# Altium schematic sheet Peripherals Schematic.SchDoc("Peripherals Schematic")
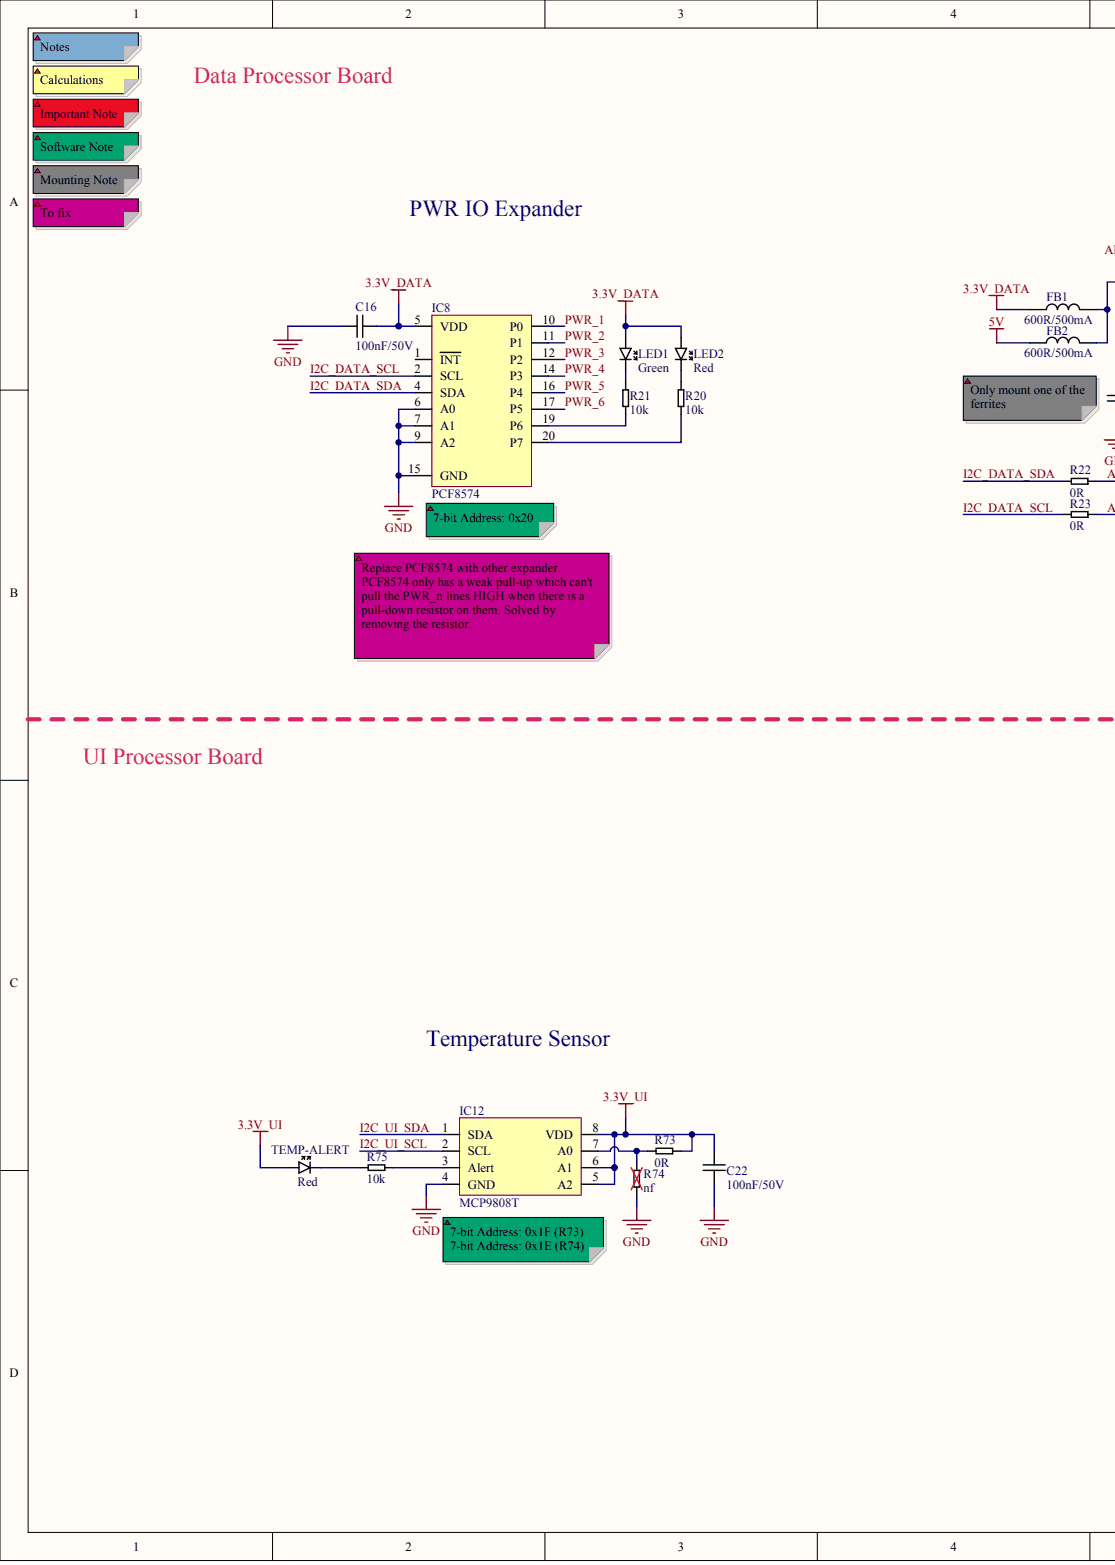
[diagram p0: Peripherals Schematic.SchDoc, left]
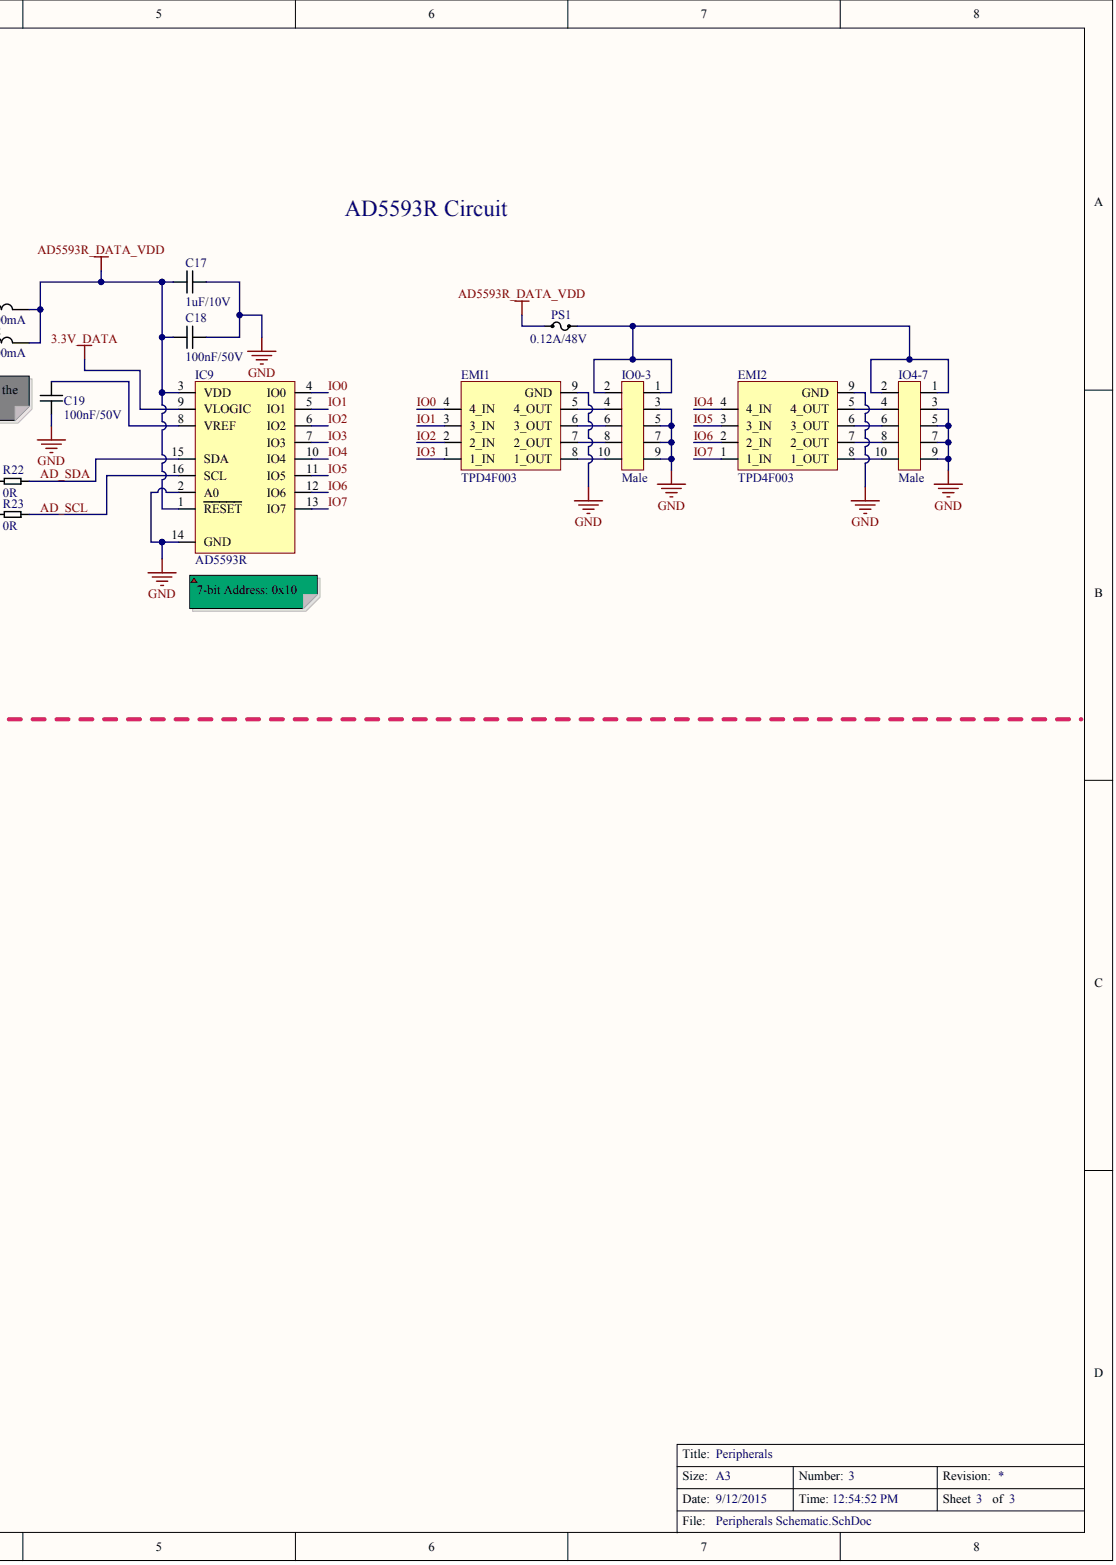
[diagram p1: Peripherals Schematic.SchDoc, right]

## Parts
C16  value 100nF/50V  [0603]  (hidden comment: Cap_0603_100nF/50V)
C17  value 1uF/10V  [0603]  (hidden comment: Cap_0603_1uF/10V)
C18  value 100nF/50V  [0603]  (hidden comment: Cap_0603_100nF/50V_X7R)
C19  value 100nF/50V  [0603]  (hidden comment: Cap_0603_100nF/50V)
C22  value 100nF/50V  [0603]  (hidden comment: Cap_0603_100nF/50V)
EMI1  value TPD4F003  [WSON-8]  (hidden comment: EMI Filter 4ch Pi)
EMI2  value TPD4F003  [WSON-8]  (hidden comment: EMI Filter 4ch Pi)
FB1  value 600R/500mA  [0603]  (hidden comment: Ferrite_Bead_0603_600R_500mA)
FB2  value 600R/500mA  [0603]  (hidden comment: Ferrite_Bead_0603_600R_500mA)
IC8  PCF8574
IC9  AD5593R
IC12  MCP9808T
IO0-3  value Male  (hidden comment: Connector 2x5)
IO4-7  value Male  (hidden comment: Connector 2x5)
LED1  value Green  (hidden comment: LED_0603_Green)
LED2  value Red  (hidden comment: LED_0603_Red)
PS1  value 0.12A/48V  [SMD 1206]  (hidden comment: Polyswitch_1206_0.12Ahold_48V)
R20  value 10k  [0603]  (hidden comment: Res_0603_10k)
R21  value 10k  [0603]  (hidden comment: Res_0603_10k)
R22  value 0R  [0603]  (hidden comment: Res_0603_0R)
R23  value 0R  [0603]  (hidden comment: Res_0603_0R)
R73  value 0R  [0603]  (hidden comment: Res_0603_0R)
R74  value nf  [0603]  (hidden comment: Res_0603_nf)
R75  value 10k  [0603]  (hidden comment: Res_0603_10k)
TEMP-ALERT  value Red  (hidden comment: LED_0603_Red)

## Nets
3.3V_DATA: C16.1 FB1.1 IC8.5(VDD) IC9.9(VLOGIC) LED1.ANODE LED2.ANODE
3.3V_UI: C22.2 IC12.5(A2) IC12.6(A1) IC12.8(VDD) R73.2 TEMP-ALERT.ANODE
5V: FB2.1
AD5593R_DATA_VDD: C17.2 C18.2 FB1.2 FB2.2 IC9.1(RESET) IC9.3(VDD) PS1.1
GND: C16.2 C17.1 C18.1 C19.2 C22.1 EMI1.9(GND) EMI2.9(GND) IC8.6(A0) IC8.7(A1) IC8.9(A2) IC8.15(GND) IC9.2(A0) IC9.14(GND) IC12.4(GND) IO0-3.3 IO0-3.5 IO0-3.7 IO0-3.9 IO4-7.3 IO4-7.5 IO4-7.7 IO4-7.9 R74.1
AD_SCL: IC9.16(SCL) R23.2
AD_SDA: IC9.15(SDA) R22.2
I2C_DATA_SCL: IC8.2(SCL) R23.1
I2C_DATA_SDA: IC8.4(SDA) R22.1
I2C_UI_SCL: IC12.2(SCL)
I2C_UI_SDA: IC12.1(SDA)
IO0: EMI1.4(4_IN) IC9.4(IO0)
IO1: EMI1.3(3_IN) IC9.5(IO1)
IO2: EMI1.2(2_IN) IC9.6(IO2)
IO3: EMI1.1(1_IN) IC9.7(IO3)
IO4: EMI2.4(4_IN) IC9.10(IO4)
IO5: EMI2.3(3_IN) IC9.11(IO5)
IO6: EMI2.2(2_IN) IC9.12(IO6)
IO7: EMI2.1(1_IN) IC9.13(IO7)
PWR_1: IC8.10(P0)
PWR_2: IC8.11(P1)
PWR_3: IC8.12(P2)
PWR_4: IC8.14(P3)
PWR_5: IC8.16(P4)
PWR_6: IC8.17(P5)
NetC19_1: C19.1 IC9.8(VREF)
NetEMI1_5: EMI1.5(4_OUT) IO0-3.4
NetEMI1_6: EMI1.6(3_OUT) IO0-3.6
NetEMI1_7: EMI1.7(2_OUT) IO0-3.8
NetEMI1_8: EMI1.8(1_OUT) IO0-3.10
NetEMI2_5: EMI2.5(4_OUT) IO4-7.4
NetEMI2_6: EMI2.6(3_OUT) IO4-7.6
NetEMI2_7: EMI2.7(2_OUT) IO4-7.8
NetEMI2_8: EMI2.8(1_OUT) IO4-7.10
NetIC8_19: IC8.19(P6) R21.1
NetIC8_20: IC8.20(P7) R20.1
NetIC12_3: IC12.3(Alert) R75.2
NetIC12_7: IC12.7(A0) R73.1 R74.2
NetIO0-3_1: IO0-3.1 IO0-3.2 IO4-7.1 IO4-7.2 PS1.2
NetLED1_CATHODE: LED1.CATHODE R21.2
NetLED2_CATHODE: LED2.CATHODE R20.2
NetR75_1: R75.1 TEMP-ALERT.CATHODE

Not connected: IC8 1(INT)

## Library data of the parts
C16 C18 C19 C22 = (no symbol name): Part No.: C1608X7R1H104K080AA; Manufacturer: TDK; RS: 741-4459 (500-pack); DigiKey: 445-1314-1-ND; Mouser: 810-C1608X7R1H104K; Price SEK (No VAT): 0,2
  C16: Value: 100nF/50V
C17 = (no symbol name): Part No.: C1608X5R1A105K080AC; Manufacturer: TDK; Mouser: 810-C1608X5R1A105K; Price SEK (No VAT): 1; DigiKey: 445-1321-1-ND
EMI1 EMI2 = (no symbol name): Part No.: TPD4F003DQDR; Manufacturer: TI; RS: 812-0200 (25-pack); Price SEK (No VAT): 5; DigiKey: 296-25817-1-ND; Mouser: 595-TPD4F003DQDR; Farnell: 1815758
FB1 FB2 = (no symbol name): Part No.: MMZ1608B601C; Manufacturer: TDK; RS: 786-5015; Price SEK (No VAT): 1; Mouser: 810-MMZ1608B601C; DigiKey: 445-2166-1-ND; Farnell: 1669687
IC8 = (no symbol name): Part No.: PCF8574TS/3,118; Manufacturer: NXP; RS: 510-784; DigiKey: 568-1078-1-ND; Price SEK (No VAT): 12; Datasheet: http://www.nxp.com/documents/data_sheet/PCF8574_PCF8574A.pdf; Mouser: 771-PCF8574TDK-T; Farnell: 2407151; Value: PCF8574
IC9 = (no symbol name): Part No.: AD5593RBRUZ; Manufacturer: Analog Devices; DigiKey: AD5593RBRUZ-ND; Price SEK (No VAT): 70; Datasheet: http://www.analog.com/media/en/technical-documentation/data-sheets/AD5593R.pdf; Mouser: 584-AD5593RBRUZ; RS: *; Farnell: 2451465; Value: AD5593R
IC12 = (no symbol name): Part No.: MCP9808T-E/MS; Manufacturer: Microchip; DigiKey: MCP9808-E/MS-ND; Price SEK (No VAT): 9; Datasheet: http://www.microchip.com/mymicrochip/filehandler.aspx?ddocname=en556196; Mouser: 579-MCP9808T-E/MS
LED1 = (no symbol name): Part No.: APT1608CGCK; Manufacturer: Kingbright; Mouser: 604-APT1608CGCK; Price SEK (No VAT): 1; DigiKey: 754-1116-1-ND
LED2 TEMP-ALERT = (no symbol name): Part No.: APT1608SRCPRV; Manufacturer: Kingbright; RS: 654-5420; DigiKey: 754-1122-1-ND; Price SEK (No VAT): 1; Mouser: 604-APT1608SRCPRV
PS1 = (no symbol name): Part No.: NANOSMDC012F-2; Manufacturer: TE Connectivity; RS: 782-6455; Mouser: 650-NANOSMDC012F-2; Price SEK (No VAT): 2; DigiKey: NANOSMDC012FCT-ND; Farnell: 1345937
R22 R23 R73 = (no symbol name): Part No.: CRCW06030000Z0EA; Manufacturer: Vishay; Price SEK (No VAT): 0,1; DigiKey: 541-0.0GCT-ND; Mouser: 71-CRCW0603-0-E3
R75 = (no symbol name): Part No.: CRCW060310K0FKEA; Manufacturer: Vishay; Price SEK (No VAT): 0,1; Mouser: 71-CRCW0603-10K-E3; DigiKey: 541-10.0KHCT-ND
IO0-3 IO4-7 R20 R21 R74 = (no symbol name)
  R20 R21: Price (No VAT): 0,1
  R74: Price SEK (No VAT): 0
